# Altium schematic sheet soc.SchDoc
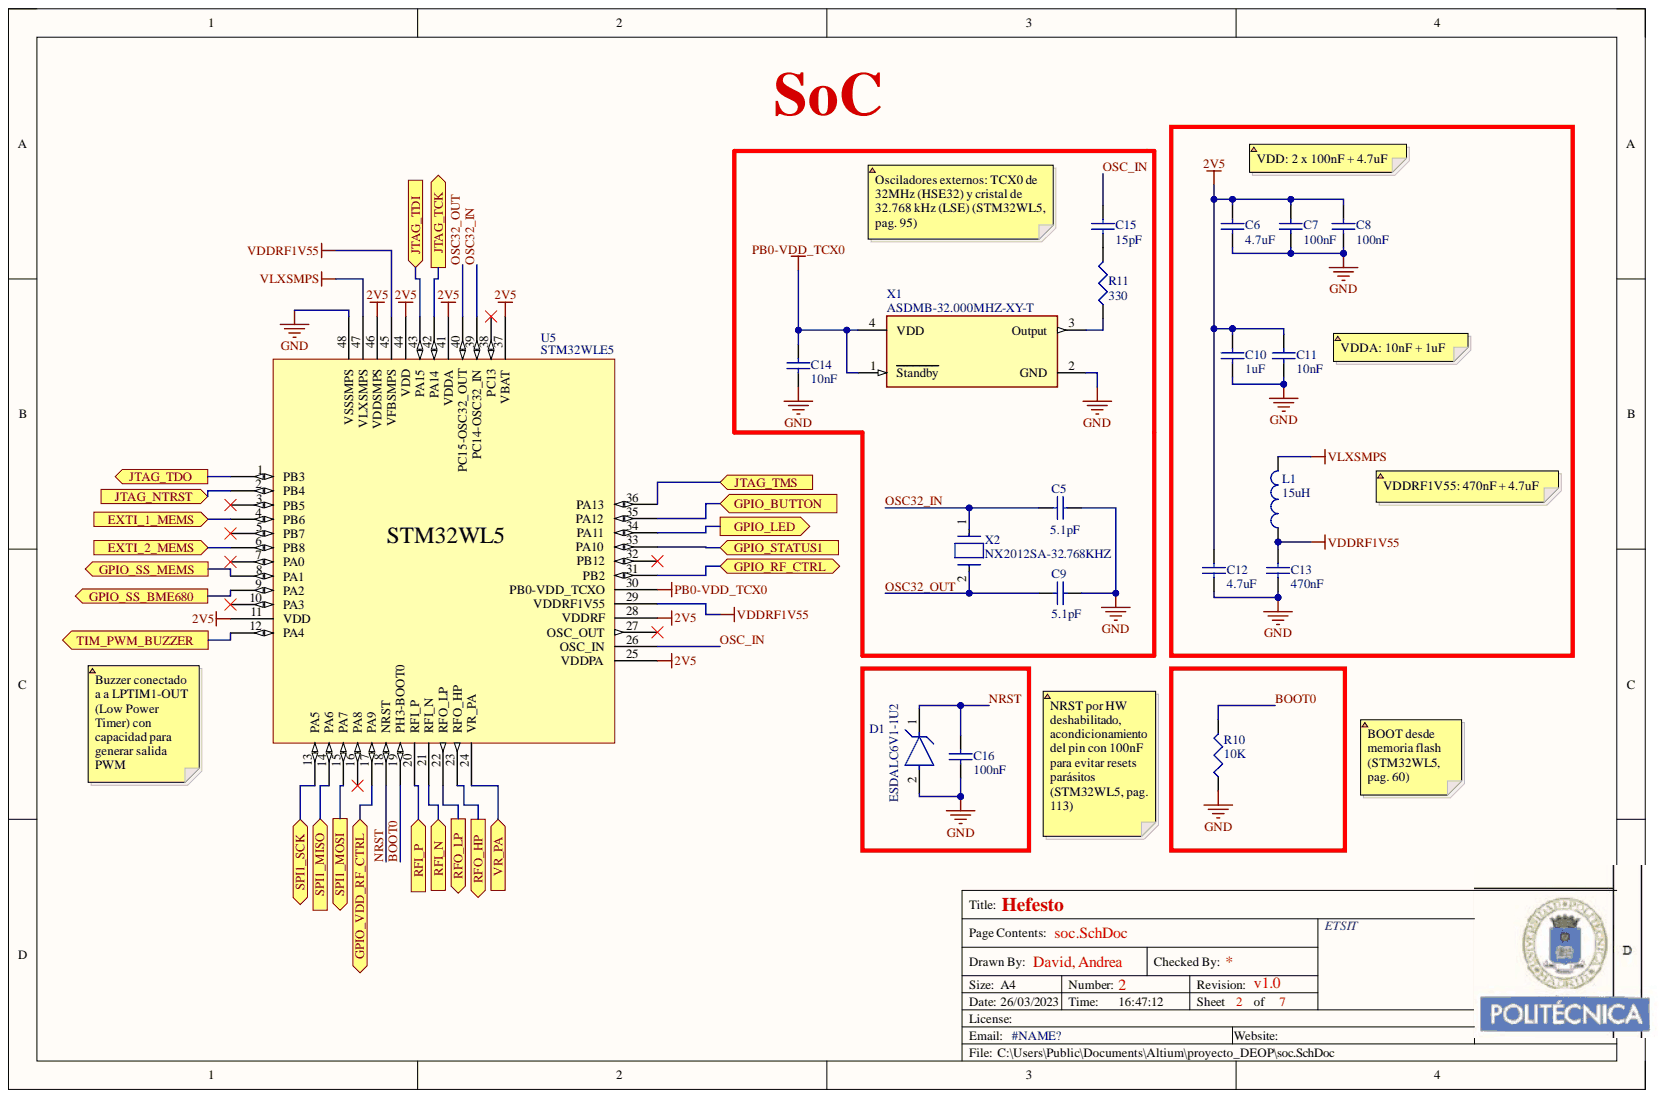
[diagram p0: soc.SchDoc]

## Parts
C5  value 5.1pF  [1608[0603]]  (hidden comment: Cap Semi)
C6  value 4.7uF  [1608[0603]]  (hidden comment: Cap Semi)
C7  value 100nF  [1608[0603]]  (hidden comment: Cap Semi)
C8  value 100nF  [1608[0603]]  (hidden comment: Cap Semi)
C9  value 5.1pF  [1608[0603]]  (hidden comment: Cap Semi)
C10  value 1uF  [1608[0603]]  (hidden comment: Cap Semi)
C11  value 10nF  [1608[0603]]  (hidden comment: Cap Semi)
C12  value 4.7uF  [1608[0603]]  (hidden comment: Cap Semi)
C13  value 470nF  [1608[0603]]  (hidden comment: Cap Semi)
C14  value 10nF  [1608[0603]]  (hidden comment: Cap Semi)
C15  value 15pF  [1608[0603]]  (hidden comment: Cap Semi)
C16  value 100nF  [1608[0603]]  (hidden comment: Cap Semi)
D1  ESDALC6V1-1U2  [FP-ST0201-MFG]
L1  value 15uH  [0603]  (hidden comment: Inductor)
R10  value 10K  [J1-0603]  (hidden comment: Res3)
R11  value 330  [J1-0603]  (hidden comment: Res3)
U5  STM32WLE5  [UFQFPN48]
X1  ASDMB-32.000MHZ-XY-T  [FP-ASDM-ASDMB-MFG]
X2  NX2012SA-32.768KHZ  [FP-NX2012SA-MFG]

## Ports
EXTI_1_MEMS, EXTI_2_MEMS, GPIO_BUTTON, GPIO_LED, GPIO_RF_CTRL, GPIO_SS_BME680, GPIO_SS_MEMS, GPIO_STATUS1, GPIO_VDD_RF_CTRL, JTAG_NTRST, JTAG_TCK, JTAG_TDI, JTAG_TDO, JTAG_TMS, RFI_N, RFI_P, RFO_HP, RFO_LP, SPI1_MISO, SPI1_MOSI, SPI1_SCK, TIM_PWM_BUZZER, VR_PA

## Nets
2V5: C6.2 C7.2 C8.2 C10.2 C11.2 C12.2 U5.11(VDD) U5.25(VDDPA) U5.28(VDDRF) U5.37(VBAT) U5.41(VDDA) U5.44(VDD) U5.46(VDDSMPS)
GND: C5.1 C6.1 C7.1 C8.1 C9.1 C10.1 C11.1 C12.1 C13.1 C14.1 C16.1 D1.2 R10.1 U5.48(VSSSMPS) X1.2(GND)
PB0-VDD_TCX0: C14.2 U5.30(PB0-VDD_TCXO) X1.1(Standby) X1.4(VDD)
VDDRF1V55: C13.2 L1.1 U5.29(VDDRF1V55) U5.45(VFBSMPS)
VLXSMPS: L1.2 U5.47(VLXSMPS)
BOOT0: R10.2 U5.19(PH3-BOOT0)
EXTI_1_MEMS: U5.4(PB6) ; port EXTI_1_MEMS
EXTI_2_MEMS: U5.6(PB8) ; port EXTI_2_MEMS
GPIO_BUTTON: U5.35(PA12) ; port GPIO_BUTTON
GPIO_LED: U5.34(PA11) ; port GPIO_LED
GPIO_RF_CTRL: U5.31(PB2) ; port GPIO_RF_CTRL
GPIO_SS_BME680: U5.9(PA2) ; port GPIO_SS_BME680
GPIO_SS_MEMS: U5.8(PA1) ; port GPIO_SS_MEMS
GPIO_STATUS1: U5.33(PA10) ; port GPIO_STATUS1
GPIO_VDD_RF_CTRL: U5.17(PA9) ; port GPIO_VDD_RF_CTRL
JTAG_NTRST: U5.2(PB4) ; port JTAG_NTRST
JTAG_TCK: U5.42(PA14) ; port JTAG_TCK
JTAG_TDI: U5.43(PA15) ; port JTAG_TDI
JTAG_TDO: U5.1(PB3) ; port JTAG_TDO
JTAG_TMS: U5.36(PA13) ; port JTAG_TMS
NRST: C16.2 D1.1 U5.18(NRST)
OSC32_IN: C5.2 U5.39(PC14-OSC32_IN) X2.1
OSC32_OUT: C9.2 U5.40(PC15-OSC32_OUT) X2.2
OSC_IN: C15.2 U5.26(OSC_IN)
RFI_N: U5.21(RFI_N) ; port RFI_N
RFI_P: U5.20(RFI_P) ; port RFI_P
RFO_HP: U5.23(RFO_HP) ; port RFO_HP
RFO_LP: U5.22(RFO_LP) ; port RFO_LP
SPI1_MISO: U5.14(PA6) ; port SPI1_MISO
SPI1_MOSI: U5.15(PA7) ; port SPI1_MOSI
SPI1_SCK: U5.13(PA5) ; port SPI1_SCK
TIM_PWM_BUZZER: U5.12(PA4) ; port TIM_PWM_BUZZER
VR_PA: U5.24(VR_PA) ; port VR_PA
NetC15_1: C15.1 R11.2
NetR11_1: R11.1 X1.3(Output)
NetU5_3: U5.3(PB5)
NetU5_5: U5.5(PB7)
NetU5_7: U5.7(PA0)
NetU5_10: U5.10(PA3)
NetU5_16: U5.16(PA8)
NetU5_27: U5.27(OSC_OUT)
NetU5_32: U5.32(PB12)
NetU5_38: U5.38(PC13)

## Library data of the parts
C5-C16 = Cap Semi: Capacitor (Semiconductor SIM Model); Component Kind: Standard; LatestRevisionDate: 29-May-2009; LatestRevisionNote: IPC-7351 Footprint Added.; Library Name: Miscellaneous Devices.IntLib; PackageDescription: Chip Capacitor; PackageReference: C1206; Published: 8-Jun-2000; Signal Integrity: Cap Semi; Simulation: CAP; Publisher: Altium Limited
D1 = CMP-12225-000007-1: TVS DIODE 3V ST0201; Manufacturer: STMicroelectronics; Breakdown Voltage: 6.1V; Capacitance: 12pF; Direction: Unidirectional; Max Junction Temp: +125°C; Number Of Channels: 1; Peak Pulse Current: 2A; Peak Pulse Power: 20W; Value: 12pF; Automotive: No; Category: Diode; Component Kind: Standard; ComponentLink2Description: Datasheet; ComponentLink2URL: https://www.st.com/content/ccc/resource/technical/document/datasheet/90/b1/aa/a3/9f/73/4d/1e/CD00212838.pdf/files/CD00212838.pdf/jcr:content/translations/en.CD00212838.pdf; Device Class L1: Circuit Protection; Device Class L2: TVS Diodes; Device Class L3: unset; Digikey Description: TVS DIODE 3V ST0201; Height: 0.33mm; Lead Free: Yes; Library Name: ESDALC6V1-1U2.IntLib; Manufacturer Part Number: ESDALC6V1-1U2; Mouser Description: TVS Diodes - Transient Voltage Suppressors Single-Line Low Cap ESD TVS 0201; Octopart: https://octopart.com/esdalc6v1-1u2-stmicroelectronics-11912330; Package: ST0201; RoHS: TRUE; Temperature: -40°C to +125°C; Temperature Range High: +125°C; Temperature Range Low: -40°C
L1 = Inductor: Code_IEC: 1005; Code_JEITA: 0402; Component Kind: Standard; Description: Inductor; LatestRevisionDate: 29-May-2009; LatestRevisionNote: IPC-7351 Footprint Added.; Library Name: Miscellaneous Devices.IntLib; PackageDescription: Chip Inductor; PackageReference: 0402-A; Published: 8-Jun-2000; Signal Integrity: Inductor; Simulation: INDUCTOR; Publisher: Altium Limited
R10 R11 = Res3: Resistor; Component Kind: Standard; LatestRevisionDate: 29-May-2009; LatestRevisionNote: IPC-7351 Footprint Added.; Library Name: Miscellaneous Devices.IntLib; PackageDescription: Chip Resistor; PackageReference: J1-0603; Published: 8-Jun-2000; Signal Integrity: Res; Simulation: RESISTOR; Publisher: Altium Limited
X1 = CMP-27762-009266-1: MEMS Oscillator 32MHz ±10ppm LVCMOS 1.8V~3.3V SMD 2.5x2mm; Load Capacitance: 15pF; Max Supply Current: 16mA; Operating Supply Voltage: 3.6V; Case/Package: SMD/SMT; Component Kind: Standard; Frequency: 32MHz; Frequency Stability: 10ppm; Height: 0.035inch; Length: 0.098inch; Library Name: Osc 32mhz.IntLib; Max Operating Temperature: 105°C; Min Operating Temperature: -40°C; Mount: Surface Mount; Number of Pins: 4; Packaging: Tape and Reel; Radiation Hardening: No; RoHS Compliant: Yes; Series: Pure Silicon™ ASDMB; Termination: SMD/SMT; Width: 0.079inch
X2 = CMP-200220-000014-1: CRYSTAL 32.7680KHZ 6PF SMD; Component Kind: Standard; Library Name: Altium Content Vault
U5 = STM32WLE5: Component Kind: Standard; Library Name: DEOP2023.IntLib
